# Altium schematic sheet 01-Power.SchDoc(01-Power)
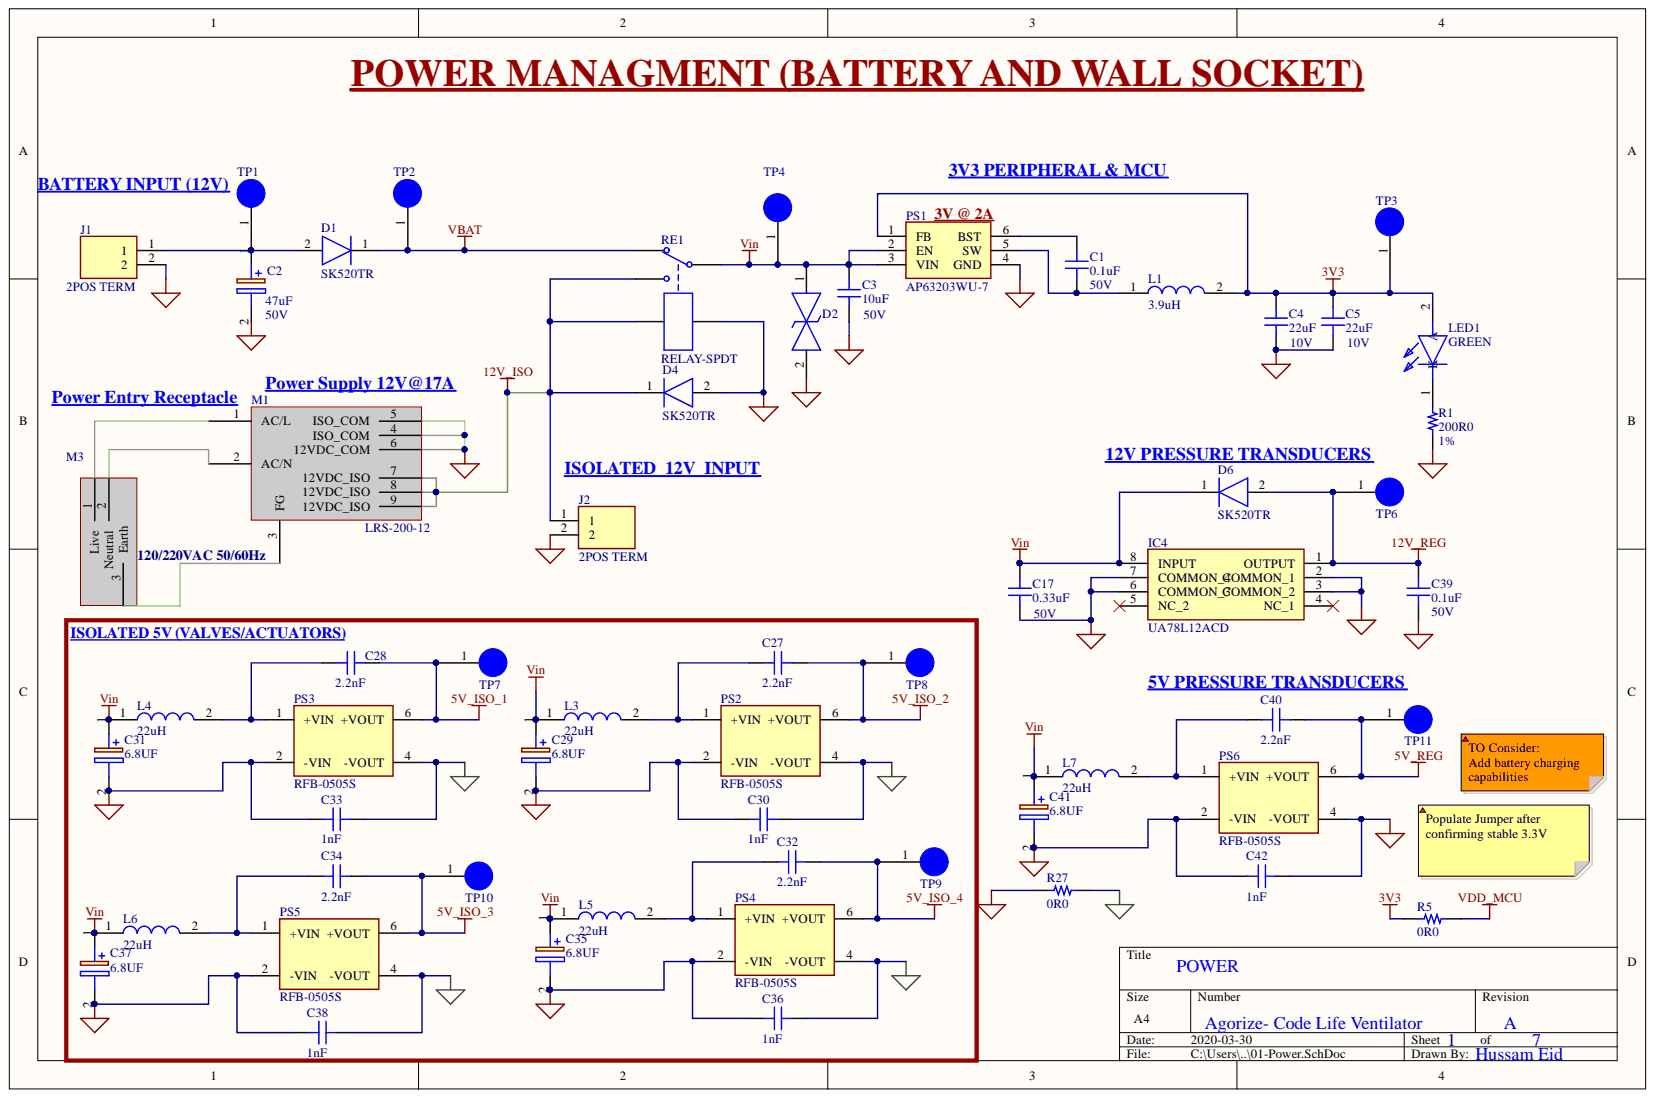
[diagram p0: 01-Power.SchDoc]

## Parts
C1  0.1uF  Voltage Rating (DC): 50V  [CAP 0603/1608]
C2  47uF  Voltage Rating (DC): 50V  [EEE1HA470XP]
C3  10uF  Voltage Rating (DC): 50V  [CAP 1206/3216]
C4  22uF  Voltage: 10V  [RES 0805/2012]
C5  22uF  Voltage: 10V  [RES 0805/2012]
C17  0.33uF  Voltage Rating (DC): 50V  [CAP 0603/1608]
C27  2.2nF  [CAP 0603/1608]
C28  2.2nF  [CAP 0603/1608]
C29  6.8UF  [CAPAE430X550N]
C30  1nF  [CAP 0603/1608]
C31  6.8UF  [CAPAE430X550N]
C32  2.2nF  [CAP 0603/1608]
C33  1nF  [CAP 0603/1608]
C34  2.2nF  [CAP 0603/1608]
C35  6.8UF  [CAPAE430X550N]
C36  1nF  [CAP 0603/1608]
C37  6.8UF  [CAPAE430X550N]
C38  1nF  [CAP 0603/1608]
C39  0.1uF  Voltage Rating (DC): 50V  [CAP 0603/1608]
C40  2.2nF  [CAP 0603/1608]
C41  6.8UF  [CAPAE430X550N]
C42  1nF  [CAP 0603/1608]
D1  SK520TR  [DIOM8060X295N]
D2  DF2B26M4SL,L3F  [TVS]  (value not drawn)
D4  SK520TR  [DIOM8060X295N]
D6  SK520TR  [DIOM8060X295N]
IC4  UA78L12ACD  [SOIC127P600X175-8N]
J1  2POS TERM  [SHDR2W110P0X500_1X2_1000X830X1140P]
J2  2POS TERM  [SHDR2W110P0X500_1X2_1000X830X1140P]
L1  3.9uH  [1255AY100MP3]
L3  22uH  [1255AY100MP3]
L4  22uH  [1255AY100MP3]
L5  22uH  [1255AY100MP3]
L6  22uH  [1255AY100MP3]
L7  22uH  [1255AY100MP3]
LED1  GREEN  [150060GS73220]
M1  Manufacturer Part Number 1: LRS-200-12  (hidden comment: 12V 204W PS)  (mechanical)
M3  Power Entry Receptacle  (mechanical)
PS1  AP63203WU-7  [SOT95P280X100-6N]
PS2  RFB-0505S  [RFB0505S]
PS3  RFB-0505S  [RFB0505S]
PS4  RFB-0505S  [RFB0505S]
PS5  RFB-0505S  [RFB0505S]
PS6  RFB-0505S  [RFB0505S]
R1  200R0  Tolerance: 1%  [RES 1206/3216]
R5  0R0  [RES 0603/1608]
R27  0R0  [RES 0603/1608]
RE1  RELAY-SPDT  [T9GV5L145]
TP1  TP  [TP]
TP2  TP  [TP]
TP3  TP  [TP]
TP4  TP  [TP]
TP6  TP  [TP]
TP7  TP  [TP]
TP8  TP  [TP]
TP9  TP  [TP]
TP10  TP  [TP]
TP11  TP  [TP]

## Nets
3V3: C4.2 C5.2 L1.2 LED1.2 PS1.1(FB) R5.1 TP3.1
5V_ISO_1: C28.2 PS3.6(+VOUT) TP7.1
5V_ISO_2: C27.2 PS2.6(+VOUT) TP8.1
5V_ISO_3: C34.2 PS5.6(+VOUT) TP10.1
5V_ISO_4: C32.2 PS4.6(+VOUT) TP9.1
5V_REG: C40.2 PS6.6(+VOUT) TP11.1
12V_ISO: D4.1 J2.1 M1.7(12VDC_ISO) M1.8(12VDC_ISO) M1.9(12VDC_ISO) RE1.3 RE1.4
12V_REG: C39.1 D6.2 IC4.1(OUTPUT) TP6.1
COM: C2.2 C3.1 C4.1 C5.1 C17.2 C29.2 C30.1 C31.2 C33.1 C35.2 C36.1 C37.2 C38.1 C39.2 C41.2 C42.1 C42.2 D2.2 D4.2 IC4.2(COMMON_1) IC4.3(COMMON_2) IC4.6(COMMON_3) IC4.7(COMMON_4) J1.2 J2.2 M1.4(ISO_COM) M1.5(ISO_COM) M1.6(12VDC_COM) PS1.4(GND) PS2.2(-VIN) PS3.2(-VIN) PS4.2(-VIN) PS5.2(-VIN) PS6.2(-VIN) PS6.4(-VOUT) R1.1 R27.1 RE1.2
COM_ISO: C30.2 C33.2 C36.2 C38.2 PS2.4(-VOUT) PS3.4(-VOUT) PS4.4(-VOUT) PS5.4(-VOUT) R27.2
VBAT: D1.1 RE1.5 TP2.1
VDD_MCU: R5.2
Vin: C3.2 C17.1 C29.1 C31.1 C35.1 C37.1 C41.1 D2.1 D6.1 IC4.8(INPUT) L3.1 L4.1 L5.1 L6.1 L7.1 PS1.2(EN) PS1.3(VIN) RE1.1 TP4.1
NetC1_1: C1.1 PS1.6(BST)
NetC1_2: C1.2 L1.1 PS1.5(SW)
NetC2_1: C2.1 D1.2 J1.1 TP1.1
NetC27_1: C27.1 L3.2 PS2.1(+VIN)
NetC28_1: C28.1 L4.2 PS3.1(+VIN)
NetC32_1: C32.1 L5.2 PS4.1(+VIN)
NetC34_1: C34.1 L6.2 PS5.1(+VIN)
NetC40_1: C40.1 L7.2 PS6.1(+VIN)
NetLED1_1: LED1.1 R1.2
NetM1_1: M1.1(AC/L) M3.1
NetM1_2: M1.2(AC/N) M3.2
NetM1_3: M1.3(FG) M3.3

Not connected: IC4 4(NC_1) 5(NC_2)

## Library data of the parts
C1 C39 = C1608X7R1E104K080AA: CAP CER 0.1UF 50V X7R 0603; Manufacturer Part Number 1: C0603C104M5RACTU; Manufacturer 1: KEMET; Tolerance: 20%; Dissipation Factor: 2.5%; Dielectric Material: Ceramic; Description 1: Cap Ceramic 0.1uF 50V X7R 20% SMD 0603 125C T/R; Database Table Name: Capacitor - Ceramic; Capacitance: 100nF; Width: 0.031inch; Voltage Rating: 50V; Thickness: 0.034inch; Termination: SMD/SMT; Supplier Part Number 1: 399-7845-1-ND; Supplier 1: Digi-Key; Stock 1: 3475152; RoHS 1: Yes; REACH SVHC: No SVHC; Radiation Hardening: No; Pricing 1: 1 = 0.10000, 10 = 0.03900, 100 = 0.01740, 500 = 0.01240, 1000 = 0.00974 (USD); Packaging: Tape and Reel; Package Quantity: 1; Mount: Surface Mount,PCB; Min Operating Temperature: -55°C; Max Operating Temperature: 125°C; Library Name: Celestial Library Database.DbLib; Length: 1.6mm; Lead Pitch: 0.7mm; Height: 0.8mm; Dielectric: X7R; Depth: 0.8mm; Contact Plating: Tin,Matte; ComponentLink16URL: http://www.farnell.com/datasheets/2746056.pdf?src-supplier=Element14; ComponentLink16Description: http://www.farnell.com/datasheets/2746056.pdf?src-supplier=Element14; ComponentLink15URL: http://www.farnell.com/datasheets/2315113.pdf?src-supplier=Newark; ComponentLink15Description: http://www.farnell.com/datasheets/2315113.pdf?src-supplier=Newark; ComponentLink14URL: http://capacitoredge.kemet.com/capedge2/DataSheet?pn=C0603C104M5RAC7867&src-supplier=Avnet; ComponentLink14Description: http://capacitoredge.kemet.com/capedge2/DataSheet?pn=C0603C104M5RAC7867&src-supplier=Avnet; ComponentLink13URL: https://www.verical.com/server-webapp/html/getDatasheet?partID=110138&src-supplier=Verical; ComponentLink13Description: https://www.verical.com/server-webapp/html/getDatasheet?partID=110138&src-supplier=Verical; ComponentLink12URL: https://api.kemet.com/component-edge/download/datasheet/C0603C104M5RACTU.pdf?src-supplier=Digi-Key; ComponentLink12Description: https://api.kemet.com/component-edge/download/datasheet/C0603C104M5RACTU.pdf?src-supplier=Digi-Key; ComponentLink11URL: http://capacitoredge.kemet.com/capedge2/DataSheet?pn=C0603C104M5RAC7867&src-supplier=Avnet+Asia; ComponentLink11Description: http://capacitoredge.kemet.com/capedge2/DataSheet?pn=C0603C104M5RAC7867&src-supplier=Avnet+Asia; ComponentLink10URL: http://www.farnell.com/datasheets/1828800.pdf?src-supplier=Newark; ComponentLink10Description: http://www.farnell.com/datasheets/1828800.pdf?src-supplier=Newark; ComponentLink9URL: http://www.farnell.com/datasheets/2746056.pdf?src-supplier=Newark; ComponentLink9Description: http://www.farnell.com/datasheets/2746056.pdf?src-supplier=Newark; ComponentLink8URL: http://components.arrow.com/part/dataview/40911037S8853915N7429?src-supplier=Arrow; ComponentLink8Description: http://components.arrow.com/part/dataview/40911037S8853915N7429?src-supplier=Arrow; ComponentLink7URL: http://www.alliedelec.com/m/d/6f3b5eb83d09db50ed28bdc730567b33.pdf?src-supplier=Allied+Electronics; ComponentLink7Description: http://www.alliedelec.com/m/d/6f3b5eb83d09db50ed28bdc730567b33.pdf?src-supplier=Allied+Electronics; ComponentLink6URL: http://www.mouser.com/ds/2/212/KEM_C1002_X7R_SMD-1102033.pdf?src-supplier=Mouser; ComponentLink6Description: http://www.mouser.com/ds/2/212/KEM_C1002_X7R_SMD-1102033.pdf?src-supplier=Mouser; ComponentLink5URL: http://components.arrow.com/part/dataview/0323_00313986?src-supplier=Arrow+Europe; ComponentLink5Description: http://components.arrow.com/part/dataview/0323_00313986?src-supplier=Arrow+Europe; ComponentLink4URL: http://www.farnell.com/datasheets/2746056.pdf?src-supplier=Farnell; ComponentLink4Description: http://www.farnell.com/datasheets/2746056.pdf?src-supplier=Farnell; ComponentLink3URL: https://www.verical.com/server-webapp/html/getDatasheet?partID=626892&src-supplier=Verical; ComponentLink3Description: https://www.verical.com/server-webapp/html/getDatasheet?partID=626892&src-supplier=Verical; ComponentLink2URL: http://digikey.com/product-detail/en/C1608X7R1E104K080AA/445-1316-1-ND/567697; ComponentLink2Description: Digi-Key listing; ComponentLink1URL: https://product.tdk.com/info/en/catalog/datasheets/mlcc_commercial_general_en.pdf; ComponentLink1Description: Datasheet; Component Kind: Standard; Case Code (Metric): 1608; Case Code (Imperial): 0603; Case/Package: 0603
C2 = EEE-1HA470XP: CAP ALUM 47UF 20% 50V SMD; Manufacturer Part Number 1: EEE-1HA470XAP; Manufacturer 1: Panasonic; Ripple Current: 105mA; Life (Hours): 2000Hour; Leakage Current: 3uA; Lead Diameter: 0.65mm; Dissipation Factor: 0.14%; Voltage Rating: 50V; Voltage: 50V; Tolerance: 20%; Termination: SMD/SMT; Supplier Part Number 1: PCE4622CT-ND; Supplier 1: Digi-Key; Stock 1: 1561; RoHS 1: Yes; Radiation Hardening: No; Pricing 1: 1 = 0.43000, 10 = 0.30600, 100 = 0.20180 (USD); Packaging: Tape and Reel; Package Quantity: 1; Number of Pins: 8; Mount: Surface Mount,PCB; Min Operating Temperature: -40°C; Max Operating Temperature: 85°C; Length: 8mm; Lead Pitch: 1.8mm; Lead Free: Lead Free; Height - Seated (Max): 0.303inch; Height: 7.8mm; Diameter: 6.3mm; Description 1: CAP ALUM 47UF 20% 50V SMD; ComponentLink5URL: https://datasheet.ciiva.com/2985/aba0000ce115-2985176.pdf?src-supplier=Avnet; ComponentLink5Description: https://datasheet.ciiva.com/2985/aba0000ce115-2985176.pdf?src-supplier=Avnet; ComponentLink4URL: https://datasheet.ciiva.com/30171/aba0000ce115-30171439.pdf?src-supplier=Onlinecomponents.com; ComponentLink4Description: https://datasheet.ciiva.com/30171/aba0000ce115-30171439.pdf?src-supplier=Onlinecomponents.com; ComponentLink3URL: https://datasheet.ciiva.com/14605/70255709-14605342.pdf?src-supplier=Allied+Electronics; ComponentLink3Description: https://datasheet.ciiva.com/14605/70255709-14605342.pdf?src-supplier=Allied+Electronics; ComponentLink2URL: https://datasheet.ciiva.com/17535/aba0000ce115-17535690.pdf?src-supplier=Avnet+Europe; ComponentLink2Description: https://datasheet.ciiva.com/17535/aba0000ce115-17535690.pdf?src-supplier=Avnet+Europe; ComponentLink1URL: https://datasheet.ciiva.com/2985/aba0000ce115-2985177.pdf?src-supplier=Avnet; ComponentLink1Description: https://datasheet.ciiva.com/2985/aba0000ce115-2985177.pdf?src-supplier=Avnet; Component Kind: Standard; Case/Package: Radial; Capacitance: 47uF
C3 = C1206C105M3RACTU: CAP CER 10UF 25V X7R 1206; Manufacturer Part Number 1: CL31A106MBHNNNE; Manufacturer 1: Samsung; Tolerance: 20%; Dielectric: X5R; Description 1: CAP CER 10UF 50V X5R 1206; Database Table Name: Capacitor - Ceramic; Width: 0.063inch; Voltage Rating: 50V; Thickness: 0.071inch; Supplier Part Number 1: 1276-6736-1-ND; Supplier 1: Digi-Key; Stock 1: 854671; RoHS 1: Yes; Pricing 1: 1 = 0.34000, 10 = 0.23000, 100 = 0.13040, 500 = 0.09206, 1000 = 0.08055 (USD); Packaging: Tape and Reel; Mount: Surface Mount; Min Operating Temperature: -55°C; Max Operating Temperature: 85°C; Library Name: Celestial Library Database.DbLib; Length: 0.126inch; ComponentLink3URL: http://www.samsungsem.com/kr/support/product-search/mlcc/CL31A106MBHNNNE.jsp?src-supplier=Digi-Key; ComponentLink3Description: http://www.samsungsem.com/kr/support/product-search/mlcc/CL31A106MBHNNNE.jsp?src-supplier=Digi-Key; ComponentLink2URL: http://digikey.com/product-detail/en/C1206C105M3RACTU/399-8148-2-ND/2215101; ComponentLink2Description: Digi-Key listing; ComponentLink1URL: http://www.kemet.com/docfinder?Partnumber=C1206C105M3RACTU; ComponentLink1Description: Datasheet; Component Kind: Standard; Case Code (Metric): 3216; Case Code (Imperial): 1206; Case/Package: 1206; Capacitance: 10uF
C4 C5 = C2012X7R1H104K085AA: CAP CER 22UF 10V X5R 0805; Manufacturer Part Number 1: LMK212BBJ226MG-T; Manufacturer 1: Taiyo Yuden; Tolerance: 20%; Description 1: CAP ,MLCC ,0805,10V,X5R,22 F 20% ,T&R; Database Table Name: Capacitor - Ceramic; Supplier Part Number 1: 587-5464-1-ND; Supplier 1: Digi-Key; Stock 1: 35; RoHS 1: Yes; Pricing 1: 1 = 0.30000, 10 = 0.20600, 100 = 0.11660, 500 = 0.08228, 1000 = 0.07200 (USD); Mount: Surface Mount; Min Operating Temperature: -55°C; Max Operating Temperature: 85°C; Library Name: Celestial Library Database.DbLib; Length: 2mm; Height: 1.25mm; Dielectric: X5R; Depth: 1.25mm; ComponentLink8URL: https://ds.yuden.co.jp/TYCOMPAS/ut/detail?pn=LMK212BBJ226MG-T &amp;u=M&src-supplier=Digi-Key; ComponentLink8Description: https://ds.yuden.co.jp/TYCOMPAS/ut/detail?pn=LMK212BBJ226MG-T &amp;u=M&src-supplier=Digi-Key; ComponentLink7URL: http://ds.yuden.co.jp/TYCOMPAS/ut/detail.do?productNo=LMK212BBJ226MG-T&fileName=LMK212BBJ226MG-T_SS&mode=specSheetDownload&src-supplier=Digi-Key; ComponentLink7Description: http://ds.yuden.co.jp/TYCOMPAS/ut/detail.do?productNo=LMK212BBJ226MG-T&fileName=LMK212BBJ226MG-T_SS&mode=specSheetDownload&src-supplier=Digi-Key; ComponentLink6URL: https://datasheet.ciiva.com/26787/cl21a226mqqnnne-26787006.pdf?src-supplier=IIIC; ComponentLink6Description: https://datasheet.ciiva.com/26787/cl21a226mqqnnne-26787006.pdf?src-supplier=IIIC; ComponentLink5URL: https://datasheet.ciiva.com/16361/cl21a226mqqnnne-16361189.pdf?src-supplier=Future+Electronics; ComponentLink5Description: https://datasheet.ciiva.com/16361/cl21a226mqqnnne-16361189.pdf?src-supplier=Future+Electronics; ComponentLink4URL: https://datasheet.ciiva.com/26838/getdatasheetpartid-357607-26838611.pdf?src-supplier=Verical; ComponentLink4Description: https://datasheet.ciiva.com/26838/getdatasheetpartid-357607-26838611.pdf?src-supplier=Verical; ComponentLink3URL: https://datasheet.ciiva.com/25796/cl21a226mqqnnne-25796195.pdf?src-supplier=Digi-Key; ComponentLink3Description: https://datasheet.ciiva.com/25796/cl21a226mqqnnne-25796195.pdf?src-supplier=Digi-Key; ComponentLink2URL: http://digikey.com/product-detail/en/C2012X7R1H104K085AA/445-7534-2-ND/2732969; ComponentLink2Description: Digi-Key listing; ComponentLink1URL: https://product.tdk.com/info/en/catalog/datasheets/mlcc_commercial_general_en.pdf; ComponentLink1Description: Datasheet; Component Kind: Standard; Case/Package: 0805; Capacitance: 22uF
C17 = C1608X7R1E104K080AA: CAP CER 0.33UF 50V X5R 0603; Manufacturer Part Number 1: C1608X5R1H334K080AB; Manufacturer 1: TDK; Tolerance: 10%; Dielectric Material: Ceramic; Description 1: TDK         C1608X5R1H334K080AB            SMD Multilayer Ceramic Capacitor, C Series, 0.33 F,  10%, X5R, 50 V, 0603 [1608 Metric]; Database Table Name: Capacitor - Ceramic; Capacitance: 330nF; Width: 0.031inch; Weight: 7.1E-05oz; Voltage Rating: 50V; Thickness: 0.035inch; Termination: SMD/SMT; Supplier Part Number 1: 445-7462-1-ND; Supplier 1: Digi-Key; Stock 1: 12717; RoHS 1: Yes; REACH SVHC: No SVHC; Radiation Hardening: No; Pricing 1: 1 = 0.23000, 10 = 0.15700, 100 = 0.07930, 500 = 0.05428, 1000 = 0.04802 (USD); Packaging: Tape and Reel; Package Quantity: 1; Number of Pins: 2; Mount: Surface Mount; Min Operating Temperature: -55°C; Max Operating Temperature: 85°C; Library Name: Celestial Library Database.DbLib; Length: 1.6mm; Lead Free: Lead Free; Height: 0.8mm; Dielectric: X5R; Depth: 0.8mm; Composition: Ceramic; ComponentLink20URL: https://datasheet.ciiva.com/7975/1796654-7975053.pdf?src-supplier=Element14; ComponentLink20Description: https://datasheet.ciiva.com/7975/1796654-7975053.pdf?src-supplier=Element14; ComponentLink19URL: https://datasheet.ciiva.com/22694/1796654-22694123.pdf?src-supplier=Element14; ComponentLink19Description: https://datasheet.ciiva.com/22694/1796654-22694123.pdf?src-supplier=Element14; ComponentLink18URL: https://datasheet.ciiva.com/6251/tdkmlcccaprange-6251126.pdf?src-supplier=Digi-Key; ComponentLink18Description: https://datasheet.ciiva.com/6251/tdkmlcccaprange-6251126.pdf?src-supplier=Digi-Key; ComponentLink17URL: https://datasheet.ciiva.com/26919/getdatasheetpartid-755505-26919191.pdf?src-supplier=Verical; ComponentLink17Description: https://datasheet.ciiva.com/26919/getdatasheetpartid-755505-26919191.pdf?src-supplier=Verical; ComponentLink16URL: http://www.farnell.com/datasheets/2746056.pdf?src-supplier=Element14; ComponentLink16Description: http://www.farnell.com/datasheets/2746056.pdf?src-supplier=Element14; ComponentLink15URL: http://www.farnell.com/datasheets/2315113.pdf?src-supplier=Newark; ComponentLink15Description: http://www.farnell.com/datasheets/2315113.pdf?src-supplier=Newark; ComponentLink14URL: http://capacitoredge.kemet.com/capedge2/DataSheet?pn=C0603C104M5RAC7867&src-supplier=Avnet; ComponentLink14Description: http://capacitoredge.kemet.com/capedge2/DataSheet?pn=C0603C104M5RAC7867&src-supplier=Avnet; ComponentLink13URL: https://www.verical.com/server-webapp/html/getDatasheet?partID=110138&src-supplier=Verical; ComponentLink13Description: https://www.verical.com/server-webapp/html/getDatasheet?partID=110138&src-supplier=Verical; ComponentLink12URL: https://api.kemet.com/component-edge/download/datasheet/C0603C104M5RACTU.pdf?src-supplier=Digi-Key; ComponentLink12Description: https://api.kemet.com/component-edge/download/datasheet/C0603C104M5RACTU.pdf?src-supplier=Digi-Key; ComponentLink11URL: http://capacitoredge.kemet.com/capedge2/DataSheet?pn=C0603C104M5RAC7867&src-supplier=Avnet+Asia; ComponentLink11Description: http://capacitoredge.kemet.com/capedge2/DataSheet?pn=C0603C104M5RAC7867&src-supplier=Avnet+Asia; ComponentLink10URL: http://www.farnell.com/datasheets/1828800.pdf?src-supplier=Newark; ComponentLink10Description: http://www.farnell.com/datasheets/1828800.pdf?src-supplier=Newark; ComponentLink9URL: http://www.farnell.com/datasheets/2746056.pdf?src-supplier=Newark; ComponentLink9Description: http://www.farnell.com/datasheets/2746056.pdf?src-supplier=Newark; ComponentLink8URL: http://components.arrow.com/part/dataview/40911037S8853915N7429?src-supplier=Arrow; ComponentLink8Description: http://components.arrow.com/part/dataview/40911037S8853915N7429?src-supplier=Arrow; ComponentLink7URL: http://www.alliedelec.com/m/d/6f3b5eb83d09db50ed28bdc730567b33.pdf?src-supplier=Allied+Electronics; ComponentLink7Description: http://www.alliedelec.com/m/d/6f3b5eb83d09db50ed28bdc730567b33.pdf?src-supplier=Allied+Electronics; ComponentLink6URL: http://www.mouser.com/ds/2/212/KEM_C1002_X7R_SMD-1102033.pdf?src-supplier=Mouser; ComponentLink6Description: http://www.mouser.com/ds/2/212/KEM_C1002_X7R_SMD-1102033.pdf?src-supplier=Mouser; ComponentLink5URL: http://components.arrow.com/part/dataview/0323_00313986?src-supplier=Arrow+Europe; ComponentLink5Description: http://components.arrow.com/part/dataview/0323_00313986?src-supplier=Arrow+Europe; ComponentLink4URL: http://www.farnell.com/datasheets/2746056.pdf?src-supplier=Farnell; ComponentLink4Description: http://www.farnell.com/datasheets/2746056.pdf?src-supplier=Farnell; ComponentLink3URL: https://www.verical.com/server-webapp/html/getDatasheet?partID=626892&src-supplier=Verical; ComponentLink3Description: https://www.verical.com/server-webapp/html/getDatasheet?partID=626892&src-supplier=Verical; ComponentLink2URL: http://digikey.com/product-detail/en/C1608X7R1E104K080AA/445-1316-1-ND/567697; ComponentLink2Description: Digi-Key listing; ComponentLink1URL: https://product.tdk.com/info/en/catalog/datasheets/mlcc_commercial_general_en.pdf; ComponentLink1Description: Datasheet; Component Kind: Standard; Case Code (Metric): 1608; Case Code (Imperial): 0603; Case/Package: 0603
C27 C28 C32 C34 C40 = C1608X7R1E104K080AA: CAP CER 2.2nF 50V X7R 0603; Manufacturer Part Number 1: C0603C104M5RACTU; Manufacturer 1: KEMET; Tolerance: 20%; Dissipation Factor: 2.5%; Dielectric Material: Ceramic; Description 1: Cap Ceramic 0.1uF 50V X7R 20% SMD 0603 125C T/R; Database Table Name: Capacitor - Ceramic; Capacitance: 100nF; Width: 0.031inch; Voltage Rating (DC): 50V; Voltage Rating: 50V; Thickness: 0.034inch; Termination: SMD/SMT; Supplier Part Number 1: 399-7845-1-ND; Supplier 1: Digi-Key; Stock 1: 3158249; RoHS 1: Yes; REACH SVHC: No SVHC; Radiation Hardening: No; Pricing 1: 1 = 0.10000, 10 = 0.03900, 100 = 0.01740, 500 = 0.01240, 1000 = 0.00974 (USD); Packaging: Tape and Reel; Package Quantity: 1; Mount: Surface Mount,PCB; Min Operating Temperature: -55°C; Max Operating Temperature: 125°C; Library Name: Celestial Library Database.DbLib; Length: 1.6mm; Lead Pitch: 0.7mm; Height: 0.8mm; Dielectric: X7R; Depth: 0.8mm; Contact Plating: Tin,Matte; ComponentLink16URL: http://www.farnell.com/datasheets/2746056.pdf?src-supplier=Element14; ComponentLink16Description: http://www.farnell.com/datasheets/2746056.pdf?src-supplier=Element14; ComponentLink15URL: http://www.farnell.com/datasheets/2315113.pdf?src-supplier=Newark; ComponentLink15Description: http://www.farnell.com/datasheets/2315113.pdf?src-supplier=Newark; ComponentLink14URL: http://capacitoredge.kemet.com/capedge2/DataSheet?pn=C0603C104M5RAC7867&src-supplier=Avnet; ComponentLink14Description: http://capacitoredge.kemet.com/capedge2/DataSheet?pn=C0603C104M5RAC7867&src-supplier=Avnet; ComponentLink13URL: https://www.verical.com/server-webapp/html/getDatasheet?partID=110138&src-supplier=Verical; ComponentLink13Description: https://www.verical.com/server-webapp/html/getDatasheet?partID=110138&src-supplier=Verical; ComponentLink12URL: https://api.kemet.com/component-edge/download/datasheet/C0603C104M5RACTU.pdf?src-supplier=Digi-Key; ComponentLink12Description: https://api.kemet.com/component-edge/download/datasheet/C0603C104M5RACTU.pdf?src-supplier=Digi-Key; ComponentLink11URL: http://capacitoredge.kemet.com/capedge2/DataSheet?pn=C0603C104M5RAC7867&src-supplier=Avnet+Asia; ComponentLink11Description: http://capacitoredge.kemet.com/capedge2/DataSheet?pn=C0603C104M5RAC7867&src-supplier=Avnet+Asia; ComponentLink10URL: http://www.farnell.com/datasheets/1828800.pdf?src-supplier=Newark; ComponentLink10Description: http://www.farnell.com/datasheets/1828800.pdf?src-supplier=Newark; ComponentLink9URL: http://www.farnell.com/datasheets/2746056.pdf?src-supplier=Newark; ComponentLink9Description: http://www.farnell.com/datasheets/2746056.pdf?src-supplier=Newark; ComponentLink8URL: http://components.arrow.com/part/dataview/40911037S8853915N7429?src-supplier=Arrow; ComponentLink8Description: http://components.arrow.com/part/dataview/40911037S8853915N7429?src-supplier=Arrow; ComponentLink7URL: http://www.alliedelec.com/m/d/6f3b5eb83d09db50ed28bdc730567b33.pdf?src-supplier=Allied+Electronics; ComponentLink7Description: http://www.alliedelec.com/m/d/6f3b5eb83d09db50ed28bdc730567b33.pdf?src-supplier=Allied+Electronics; ComponentLink6URL: http://www.mouser.com/ds/2/212/KEM_C1002_X7R_SMD-1102033.pdf?src-supplier=Mouser; ComponentLink6Description: http://www.mouser.com/ds/2/212/KEM_C1002_X7R_SMD-1102033.pdf?src-supplier=Mouser; ComponentLink5URL: http://components.arrow.com/part/dataview/0323_00313986?src-supplier=Arrow+Europe; ComponentLink5Description: http://components.arrow.com/part/dataview/0323_00313986?src-supplier=Arrow+Europe; ComponentLink4URL: http://www.farnell.com/datasheets/2746056.pdf?src-supplier=Farnell; ComponentLink4Description: http://www.farnell.com/datasheets/2746056.pdf?src-supplier=Farnell; ComponentLink3URL: https://www.verical.com/server-webapp/html/getDatasheet?partID=626892&src-supplier=Verical; ComponentLink3Description: https://www.verical.com/server-webapp/html/getDatasheet?partID=626892&src-supplier=Verical; ComponentLink2URL: http://digikey.com/product-detail/en/C1608X7R1E104K080AA/445-1316-1-ND/567697; ComponentLink2Description: Digi-Key listing; ComponentLink1URL: https://product.tdk.com/info/en/catalog/datasheets/mlcc_commercial_general_en.pdf; ComponentLink1Description: Datasheet; Component Kind: Standard; Case Code (Metric): 1608; Case Code (Imperial): 0603; Case/Package: 0603
C29 C31 C35 C37 C41 = CAP_ELECTROLYTIC: CAP 6.8 UF 20% 50 V; Manufacturer Part Number 1: 865080642005; Manufacturer 1: Wurth Electronics; Voltage Rating (DC): 50V; Ripple Current (AC): 75mA; Polarity: Polar; Material: Aluminium; Life (Hours): 2000Hour; Impedance: 3.8R; Voltage Rating: 50V; Tolerance: 20%; Supplier Part Number 1: 732-8450-1-ND; Supplier 1: Digi-Key; Stock 1: 76; RoHS 1: Yes; Ripple Current: 75mA; REACH SVHC: Unknown; Pricing 1: 1 = 0.20000, 50 = 0.17000, 100 = 0.14800, 250 = 0.13200, 500 = 0.11500 (USD); Packaging: Cut Tape; Package Quantity: 1000; Mount: Surface Mount; Min Operating Temperature: -55°C; Max Operating Temperature: 105°C; Library Name: Schlib1.SchLib; Height - Seated (Max): 0.217inch; Height: 5.5mm; Diameter: 5mm; Description 1: CAP 6.8 UF 20% 50 V; ComponentLink1URL: https://datasheet.ciiva.com/48693/001280473-da-01-en-wcap-asli-alu-elec-caps-865080642005-48693548.pdf?src-supplier=Conrad; ComponentLink1Description: https://datasheet.ciiva.com/48693/001280473-da-01-en-wcap-asli-alu-elec-caps-865080642005-48693548.pdf?src-supplier=Conrad; Component Kind: Standard; Case/Package: Radial; Capacitance: 6.8uF
C30 C33 C36 C38 C42 = C1608X7R1E104K080AA: CAP CER 1nF 50V X7R 0603; Manufacturer Part Number 1: C0603C104M5RACTU; Manufacturer 1: KEMET; Tolerance: 20%; Dissipation Factor: 2.5%; Dielectric Material: Ceramic; Description 1: Cap Ceramic 0.1uF 50V X7R 20% SMD 0603 125C T/R; Database Table Name: Capacitor - Ceramic; Capacitance: 100nF; Width: 0.031inch; Voltage Rating (DC): 50V; Voltage Rating: 50V; Thickness: 0.034inch; Termination: SMD/SMT; Supplier Part Number 1: 399-7845-1-ND; Supplier 1: Digi-Key; Stock 1: 3158249; RoHS 1: Yes; REACH SVHC: No SVHC; Radiation Hardening: No; Pricing 1: 1 = 0.10000, 10 = 0.03900, 100 = 0.01740, 500 = 0.01240, 1000 = 0.00974 (USD); Packaging: Tape and Reel; Package Quantity: 1; Mount: Surface Mount,PCB; Min Operating Temperature: -55°C; Max Operating Temperature: 125°C; Library Name: Celestial Library Database.DbLib; Length: 1.6mm; Lead Pitch: 0.7mm; Height: 0.8mm; Dielectric: X7R; Depth: 0.8mm; Contact Plating: Tin,Matte; ComponentLink16URL: http://www.farnell.com/datasheets/2746056.pdf?src-supplier=Element14; ComponentLink16Description: http://www.farnell.com/datasheets/2746056.pdf?src-supplier=Element14; ComponentLink15URL: http://www.farnell.com/datasheets/2315113.pdf?src-supplier=Newark; ComponentLink15Description: http://www.farnell.com/datasheets/2315113.pdf?src-supplier=Newark; ComponentLink14URL: http://capacitoredge.kemet.com/capedge2/DataSheet?pn=C0603C104M5RAC7867&src-supplier=Avnet; ComponentLink14Description: http://capacitoredge.kemet.com/capedge2/DataSheet?pn=C0603C104M5RAC7867&src-supplier=Avnet; ComponentLink13URL: https://www.verical.com/server-webapp/html/getDatasheet?partID=110138&src-supplier=Verical; ComponentLink13Description: https://www.verical.com/server-webapp/html/getDatasheet?partID=110138&src-supplier=Verical; ComponentLink12URL: https://api.kemet.com/component-edge/download/datasheet/C0603C104M5RACTU.pdf?src-supplier=Digi-Key; ComponentLink12Description: https://api.kemet.com/component-edge/download/datasheet/C0603C104M5RACTU.pdf?src-supplier=Digi-Key; ComponentLink11URL: http://capacitoredge.kemet.com/capedge2/DataSheet?pn=C0603C104M5RAC7867&src-supplier=Avnet+Asia; ComponentLink11Description: http://capacitoredge.kemet.com/capedge2/DataSheet?pn=C0603C104M5RAC7867&src-supplier=Avnet+Asia; ComponentLink10URL: http://www.farnell.com/datasheets/1828800.pdf?src-supplier=Newark; ComponentLink10Description: http://www.farnell.com/datasheets/1828800.pdf?src-supplier=Newark; ComponentLink9URL: http://www.farnell.com/datasheets/2746056.pdf?src-supplier=Newark; ComponentLink9Description: http://www.farnell.com/datasheets/2746056.pdf?src-supplier=Newark; ComponentLink8URL: http://components.arrow.com/part/dataview/40911037S8853915N7429?src-supplier=Arrow; ComponentLink8Description: http://components.arrow.com/part/dataview/40911037S8853915N7429?src-supplier=Arrow; ComponentLink7URL: http://www.alliedelec.com/m/d/6f3b5eb83d09db50ed28bdc730567b33.pdf?src-supplier=Allied+Electronics; ComponentLink7Description: http://www.alliedelec.com/m/d/6f3b5eb83d09db50ed28bdc730567b33.pdf?src-supplier=Allied+Electronics; ComponentLink6URL: http://www.mouser.com/ds/2/212/KEM_C1002_X7R_SMD-1102033.pdf?src-supplier=Mouser; ComponentLink6Description: http://www.mouser.com/ds/2/212/KEM_C1002_X7R_SMD-1102033.pdf?src-supplier=Mouser; ComponentLink5URL: http://components.arrow.com/part/dataview/0323_00313986?src-supplier=Arrow+Europe; ComponentLink5Description: http://components.arrow.com/part/dataview/0323_00313986?src-supplier=Arrow+Europe; ComponentLink4URL: http://www.farnell.com/datasheets/2746056.pdf?src-supplier=Farnell; ComponentLink4Description: http://www.farnell.com/datasheets/2746056.pdf?src-supplier=Farnell; ComponentLink3URL: https://www.verical.com/server-webapp/html/getDatasheet?partID=626892&src-supplier=Verical; ComponentLink3Description: https://www.verical.com/server-webapp/html/getDatasheet?partID=626892&src-supplier=Verical; ComponentLink2URL: http://digikey.com/product-detail/en/C1608X7R1E104K080AA/445-1316-1-ND/567697; ComponentLink2Description: Digi-Key listing; ComponentLink1URL: https://product.tdk.com/info/en/catalog/datasheets/mlcc_commercial_general_en.pdf; ComponentLink1Description: Datasheet; Component Kind: Standard; Case Code (Metric): 1608; Case Code (Imperial): 0603; Case/Package: 0603
D1 D4 D6 = SK520TR: DIODE SCHOTTKY 200V 5A SMC; Supplier Part Number 1: 1655-1603-1-ND; Supplier 1: Digi-Key; Stock 1: 19874; RoHS 1: No; Pricing 1: 1 = 0.35000, 10 = 0.28500, 25 = 0.26040, 100 = 0.16360, 250 = 0.16176, 500 = 0.15148, 1000 = 0.10300 (USD); Packaging: Tape and Reel; Mount: Surface Mount; Max Reverse Voltage (DC): 200V; Manufacturer Part Number 1: SK520TR; Manufacturer 1: SMC; Description 1: DIODE SCHOTTKY 200V 5A SMC; ComponentLink1URL: http://www.smc-diodes.com/propdf/SK520%20N0107%20REV.A.pdf?src-supplier=Digi-Key; ComponentLink1Description: http://www.smc-diodes.com/propdf/SK520%20N0107%20REV.A.pdf?src-supplier=Digi-Key; Component Kind: Standard; Case/Package: SMC; Average Rectified Current: 5A
D2 = 1KSMB12CA: BI-DIRECTIONAL ESD PROTECTION DI; Manufacturer 1: Toshiba Semiconductor and Storage; Manufacturer Part Number 1: DF2B26M4SL,L3F; Component Kind: Standard
IC4 = UA78L12ACD: Linear Voltage Regulator IC  1 Output  100mA 8-SOIC; Manufacturer 1: Texas Instruments; RS Price/Stock: http://uk.rs-online.com/web/p/products/6616727P; RS Part Number: 6616727P; Mouser Price/Stock: https://www.mouser.com/Search/Refine.aspx?Keyword=595-UA78L12ACD; Mouser Part Number: 595-UA78L12ACD; Manufacturer Part Number 1: UA78L12ACD; Manufacturer_Part_Number: UA78L12ACD; Height: 1.75mm; Datasheet Link: http://www.ti.com/lit/ds/symlink/ua78l12a.pdf; Component Kind: Standard
J1 J2 = 1935161: TERM BLK 2POS SIDE ENTRY 5MM PCB; Manufacturer 1: Phoenix Contact; Wire Gauge (Min): 12AWG; Wire Gauge (Max): 26AWG; Voltage Rating (DC): 320V; Voltage Rating: 250V; Voltage: 300V; Number of Positions Per Level: 2; Number of Levels: 1; Max Current Rating: 16A; Description 1: Conn Terminal Blocks 2 POS 5mm Solder ST Thru-Hole 16A; Current Rating: 17.5A; Current: 18A; Connector Type: Screw; Approvals: UL; Wire/Cable Gauge: 26AWG; Width: 14.9mm; Weight: 0.067021oz; Termination: Solder; Supplier Part Number 1: 277-1667-ND; Supplier 1: Digi-Key; Stock 1: 32563; RoHS 1: Yes; REACH SVHC: Unknown; Radiation Hardening: No; Pricing 1: 1 = 0.43000, 10 = 0.41100, 50 = 0.39280, 100 = 0.38200, 250 = 0.35700, 500 = 0.32844, 1000 = 0.30350 (USD); Pitch: 5mm; Packaging: Bulk; Package Quantity: 250; Orientation: Straight; Number of Rows: 1; Number of Positions: 2; Number of Poles: 2; Number of Pins: 2; Number of Contacts: 2; Number of Circuits: 2; Mount: Through Hole; Max Supply Voltage: 250V; Material: Nylon; Manufacturer Part Number 1: 1935161; Length: 9mm; Lead Free: Lead Free; Insulation Material: Nylon; Housing Material: Polyamide; Housing Color: Green; Height: 11.3mm; Flammability Rating: UL94 V-0; Depth: 9mm; Contact Material: Copper,Tin; ComponentLink3URL: https://datasheet.ciiva.com/824/combiconcompact5-0mmseries-824222.pdf?src-supplier=Digi-Key; ComponentLink3Description: https://datasheet.ciiva.com/824/combiconcompact5-0mmseries-824222.pdf?src-supplier=Digi-Key; ComponentLink2URL: https://datasheet.ciiva.com/16047/1935161-16047247.pdf?src-supplier=Onlinecomponents.com; ComponentLink2Description: https://datasheet.ciiva.com/16047/1935161-16047247.pdf?src-supplier=Onlinecomponents.com; ComponentLink1URL: https://datasheet.ciiva.com/15169/getdatasheetpartid-177903-15169663.pdf?src-supplier=Verical; ComponentLink1Description: https://datasheet.ciiva.com/15169/getdatasheetpartid-177903-15169663.pdf?src-supplier=Verical; Component Kind: Standard; Color: Green
L1 = 1255AY-3R9N=P3: 3.9µH Shielded Wirewound Inductor 4.2A 26.4mOhm Max Nonstandard; Manufacturer 1: Murata; Tolerance: 30%; Test Frequency: 100kHz; Saturation Current: 4.7A; Description 1: FIXED IND; DC Resistance (DCR): 26.4mR; Core Material: Ferrite; Width: 0.248inch; Supplier Part Number 1: 490-14062-1-ND; Supplier 1: Digi-Key; Stock 1: 385; Shielding: Shielded; RoHS 1: Yes; Pricing 1: 1 = 0.48000, 10 = 0.42300, 100 = 0.30190, 500 = 0.25358 (USD); Packaging: Tape and Reel; Mount: Surface Mount; Min Operating Temperature: -40°C; Max Operating Temperature: 85°C; Manufacturer Part Number 1: 1255AY-3R9N=P3; Length: 0.248inch; Inductance: 3.9uH; Height - Seated (Max): 0.177inch; Current Rating: 4.2A; Composition: Wirewound; ComponentLink1URL: http://www.toko.co.jp/products/pdf/inductors/dg6045c.pdf?src-supplier=Digi-Key; ComponentLink1Description: http://www.toko.co.jp/products/pdf/inductors/dg6045c.pdf?src-supplier=Digi-Key; Component Kind: Standard; Case/Package: Nonstandard
L3-L7 = 1255AY-3R9N=P3: Inductor Unshielded Wirewound  190mA 4.81Ohm Max 0805 (2012 Metric); Manufacturer Part Number 1: CBC2012T220M; Manufacturer 1: Taiyo Yuden; Tolerance: 20%; Test Frequency: 2.52MHz; Self Resonant Frequency: 16MHz; Saturation Current: 170mA; Description 1: Inductor Power Chip Wirewound 22uH 20% 2.52MHz Ferrite 190mA 4.81Ohm DCR 0805 T/R; DC Resistance (DCR): 4.81R; Core Material: Ferrite; Width: 0.049inch; Termination: SMD/SMT; Supplier Part Number 1: 587-1604-1-ND; Supplier 1: Digi-Key; Stock 1: 8981; Shielding: Unshielded; RoHS 1: Yes; Pricing 1: 1 = 0.24000, 10 = 0.21600, 100 = 0.14860, 500 = 0.12702, 1000 = 0.09729 (USD); Packaging: Tape and Reel; Package Quantity: 3000; Number of Pins: 2; Mount: Surface Mount; Min Operating Temperature: -40°C; Max Operating Temperature: 105°C; Max DC Current: 170mA; Length: 0.079inch; Lead Free: Exempt; Inductance: 22uH; Height - Seated (Max): 0.057inch; Height: 1.25mm; Halogen Free: Halogen Free; Current Rating: 190mA; Composition: Wirewound; ComponentLink3URL: https://datasheet.ciiva.com/1040/cbc2012t220m-ss-1040471.pdf?src-supplier=Digi-Key; ComponentLink3Description: https://datasheet.ciiva.com/1040/cbc2012t220m-ss-1040471.pdf?src-supplier=Digi-Key; ComponentLink2URL: https://datasheet.ciiva.com/8505/cbc2012t220m-ss-8505651.pdf?src-supplier=Avnet; ComponentLink2Description: https://datasheet.ciiva.com/8505/cbc2012t220m-ss-8505651.pdf?src-supplier=Avnet; ComponentLink1URL: http://www.toko.co.jp/products/pdf/inductors/dg6045c.pdf?src-supplier=Digi-Key; ComponentLink1Description: http://www.toko.co.jp/products/pdf/inductors/dg6045c.pdf?src-supplier=Digi-Key; Component Kind: Standard; Case Code (Metric): 2012; Case Code (Imperial): 0805; Case/Package: 0805
LED1 = 150060GS73220: LED GREEN CLEAR 0603 SMD; Manufacturer Part Number 1: 150060VS75000; Manufacturer 1: Wurth Electronics; Wavelength: 570nm; Viewing Angle: 140°; Test Current: 20mA; Luminous Intensity: 40mcd; Lens Style: Clear,Rectangular; Forward Voltage: 2V; Forward Current: 30mA; Description 1: WURTH ELEKTRONIK - 150060VS75000 - LED, Grün, Oberflächenmontage, 603, 30 mA, 2 V, 570 nm; Width: 0.8mm; Supplier Part Number 1: 732-4980-1-ND; Supplier 1: Digi-Key; Stock 1: 29468; RoHS 1: Yes; REACH SVHC: Unknown; Pricing 1: 1 = 0.14000, 50 = 0.13300, 100 = 0.11400, 500 = 0.09900 (USD); Packaging: Tape and Reel; Package Quantity: 1; Number of Pins: 2; Mount: Surface Mount; Min Operating Temperature: -40°C; Max Operating Temperature: 85°C; Length: 1.6mm; Height: 0.7mm; Dominant Wavelength: 570nm; ComponentLink1URL: https://datasheet.ciiva.com/29562/150060vs75000-29562919.pdf?src-supplier=Digi-Key; ComponentLink1Description: https://datasheet.ciiva.com/29562/150060vs75000-29562919.pdf?src-supplier=Digi-Key; Component Kind: Standard; Color: Green; Case Code (Metric): 1608; Case Code (Imperial): 0603; Case/Package: 0603
M1 = 12V 204W PS: Enclosed AC DC Converters 1 Output 12V    17A 90 ~ 132 VAC, 180 ~ 264 VAC, 240 ~ 370 VDC Input; Manufacturer 1: Mean Well; Number of Outputs: 1; Max Output Power: 200W; Max Input Current: 4A; Isolation Voltage: 3kV; Efficiency: 87.5%; Description 1: 204W 12V 17A Single Output Switchable Power Supply; Approvals: UL; Width: 115mm; Weight: 1.455lb; Voltage - Output 1: 12V; Supplier Part Number 1: 1866-3330-ND; Supplier 1: Digi-Key; Stock 1: 186; RoHS 1: Yes; Pricing 1: 1 = 30.51000, 10 = 28.71700, 25 = 27.81920, 50 = 26.92180 (USD); Packaging: Bulk; Package Quantity: 15; Output Power: 204W; Output Current: 17A; Mount: Panel; Min Operating Temperature: -25°C; Max Operating Temperature: 70°C; Library Name: Schlib1.SchLib; Length: 215mm; Lead Free: Lead Free; Height: 30mm; Current - Output 1: 17A; ComponentLink2URL: https://datasheet.ciiva.com/43506/2219727-43506012.pdf?src-supplier=Jameco; ComponentLink2Description: https://datasheet.ciiva.com/43506/2219727-43506012.pdf?src-supplier=Jameco; ComponentLink1URL: https://datasheet.ciiva.com/43509/lrs-200-spec-43509573.pdf?src-supplier=Onlinecomponents.com; ComponentLink1Description: https://datasheet.ciiva.com/43509/lrs-200-spec-43509573.pdf?src-supplier=Onlinecomponents.com; Color: Silver
M3 = Power Entry Receptacle: Power Entry Connector Receptacle, Male Blades IEC 320-C14 Panel Mount, Flange; Manufacturer Part Number 1: FN9222B-1-06; Manufacturer 1: Schaffner; Voltage Rating (AC): 250V; Resistance: 1MR; Ratings: IP40; Number of Positions: 3; Number of Ports: 1; Max Current Rating: 1A; Inductance: 12mH; Fuse: No; Frequency: 400Hz; Description 1: PWR ENT RCPT IEC320-C14 PANEL QC; Capacitance: 100nF; Approvals: UL,CSA,ENEC,CQC,VDE; Width: 22.5mm; Voltage Rating: 250V; Termination: Solder; Supplier Part Number 1: 817-1428-ND; Supplier 1: Digi-Key; Stock 1: 242; RoHS 1: Yes; REACH SVHC: No SVHC; Radiation Hardening: No; Pricing 1: 1 = 5.75000, 10 = 5.52000, 25 = 5.06000, 50 = 4.83000, 100 = 4.60000, 250 = 4.02500, 500 = 3.91000, 1000 = 3.33500, 2500 = 3.10500 (USD); Packaging: Bulk; Package Quantity: 60; Orientation: Straight; Number of Poles: 3; Number of Contacts: 3; Mount: Panel,Flanges,Screw; Min Operating Temperature: -25°C; Max Voltage Rating (AC): 250V; Max Operating Temperature: 85°C; Library Name: Schlib1.SchLib; Height: 48mm; Gender: Male; Flammability Rating: UL94 V-2; Depth: 43.9mm; Current Rating: 1A; Current: 1A; Connector Type: Receptacle; ComponentLink5URL: https://datasheet.ciiva.com/1019/fn+9222-1019406.pdf?src-supplier=Digi-Key; ComponentLink5Description: https://datasheet.ciiva.com/1019/fn+9222-1019406.pdf?src-supplier=Digi-Key; ComponentLink4URL: https://datasheet.ciiva.com/46431/1851815-46431020.pdf?src-supplier=Element14; ComponentLink4Description: https://datasheet.ciiva.com/46431/1851815-46431020.pdf?src-supplier=Element14; ComponentLink3URL: https://datasheet.ciiva.com/30243/138index-phpid329l0w4-productsproduct70chash7322c530e83bcf7af0b2e347-30243243.pdf?src-supplier=Onlinecomponents.com; ComponentLink3Description: https://datasheet.ciiva.com/30243/138index-phpid329l0w4-productsproduct70chash7322c530e83bcf7af0b2e347-30243243.pdf?src-supplier=Onlinecomponents.com; ComponentLink2URL: https://datasheet.ciiva.com/4582/-4582696.pdf?src-supplier=Mouser; ComponentLink2Description: https://datasheet.ciiva.com/4582/-4582696.pdf?src-supplier=Mouser; ComponentLink1URL: https://datasheet.ciiva.com/14563/70027175-14563477.pdf?src-supplier=Allied+Electronics; ComponentLink1Description: https://datasheet.ciiva.com/14563/70027175-14563477.pdf?src-supplier=Allied+Electronics; Case/Package: Module
PS1 = AP63203WU-7: IC REG BUCK 3.3V 2A TSOT26; Manufacturer 1: Diodes; Description 1: AP63203 Series 3.3 V 2 A SMT Fixed Low IQ Synchronous Buck - TSOT-26; Supplier Part Number 1: AP63203WU-7DICT-ND; Supplier 1: Digi-Key; Stock 1: 8615; RoHS 1: No; Pricing 1: 1 = 0.83000, 10 = 0.74500, 25 = 0.70720, 100 = 0.54290, 250 = 0.47976, 500 = 0.45450, 1000 = 0.35350 (USD); Manufacturer Part Number 1: AP63203WU-7; ComponentLink4URL: http://www.samsungsem.com/kr/support/product-search/mlcc/CL31A106MBHNNNE.jsp?src-supplier=Digi-Key; ComponentLink4Description: http://www.samsungsem.com/kr/support/product-search/mlcc/CL31A106MBHNNNE.jsp?src-supplier=Digi-Key; ComponentLink3URL: http://www.farnell.com/datasheets/2741240.pdf?src-supplier=Newark; ComponentLink3Description: http://www.farnell.com/datasheets/2741240.pdf?src-supplier=Newark; ComponentLink2URL: https://api.kemet.com/component-edge/download/datasheet/C0603C104K5RECAUTO.pdf?src-supplier=Digi-Key; ComponentLink2Description: https://api.kemet.com/component-edge/download/datasheet/C0603C104K5RECAUTO.pdf?src-supplier=Digi-Key; ComponentLink1URL: http://www.farnell.com/datasheets/2741240.pdf?src-supplier=Farnell; ComponentLink1Description: http://www.farnell.com/datasheets/2741240.pdf?src-supplier=Farnell; Component Kind: Standard
PS2-PS6 = RFB-0505S: DC DC CONVERTER 5V 1W; Manufacturer_Name: RECOM Power; Manufacturer 1: Recom; Number of Outputs: 1; Max Output Current: 200mA; Isolation Voltage: 1kV; Efficiency: 80%; Description 1: Recom RFB 1W Isolated DC-DC Converter Through Hole, Voltage out 5V dc; Width: 6mm; Weight: 2.2g; Voltage - Output 1: 5V; Supplier Part Number 1: 945-3158-ND; Supplier 1: Digi-Key; Stock 1: 1808; RS Price/Stock: http://uk.rs-online.com/web/p/products/1855539P; RS Part Number: 1855539P; RoHS 1: No; Pricing 1: 1 = 1.54000, 5 = 1.51800, 10 = 1.49600, 25 = 1.45200, 50 = 1.40800, 100 = 1.36400, 250 = 1.32000, 500 = 1.23200 (USD); Packaging: Rail/Tube; Mouser Price/Stock: https://www.mouser.com/Search/Refine.aspx?Keyword=919-RFB-0505S; Mouser Part Number: 919-RFB-0505S; Mount: Through Hole; Min Operating Temperature: -40°C; Max Power Dissipation: 1W; Max Output Voltage: 5V; Max Operating Temperature: 85°C; Max Input Voltage: 5V; Manufacturer Part Number 1: RFB-0505S; Manufacturer_Part_Number: RFB-0505S; Library Name: Schlib1.SchLib; Length: 19.6mm; Height: 10.7mm; Features: SCP; Datasheet Link: https://componentsearchengine.com/Datasheets/1/RFB-0505S.pdf; ComponentLink1URL: https://www.recom-power.com/pdf/Econoline/RFB.pdf?src-supplier=Digi-Key; ComponentLink1Description: https://www.recom-power.com/pdf/Econoline/RFB.pdf?src-supplier=Digi-Key; Component Kind: Standard
R1 = ERJ-8ENF1002V: RES SMD 10K OHM 1% 1/4W 1206; Manufacturer Part Number 1: RC1206FR-07200RL; Manufacturer 1: Yageo; Voltage Rating (DC): 200V; Temperature Coefficient: 100ppm/°C; Resistance: 200R; Power Rating: 250mW; Description 1: Res Thick Film 1206 200 Ohm 1% 0.25W(1/4W) ±100ppm/C Molded SMD Paper T/R; Database Table Name: Resistor - Chip; Width: 1.6mm; Weight: 0.000571oz; Voltage Rating: 200V; Termination: SMD/SMT; Supplier Part Number 1: 311-200FRCT-ND; Supplier 1: Digi-Key; Stock 1: 2870115; RoHS 1: Yes; Pricing 1: 1 = 0.10000, 10 = 0.07100, 100 = 0.02910, 1000 = 0.01306, 2500 = 0.01134 (USD); Packaging: Tape and Reel; Mount: Surface Mount; Min Operating Temperature: -55°C; Military Standard: Not; Max Operating Temperature: 155°C; Library Name: Celestial Library Database.DbLib; Length: 3.1mm; Lead Free: Lead Free; Height: 0.55mm; Depth: 1.6mm; Composition: Thick Film; ComponentLink3URL: https://datasheet.ciiva.com/11808/0900766b80c6630a-11808603.pdf?src-supplier=RSComponents; ComponentLink3Description: https://datasheet.ciiva.com/11808/0900766b80c6630a-11808603.pdf?src-supplier=RSComponents; ComponentLink2URL: http://digikey.com/product-detail/en/ERJ-8ENF1002V/P10.0KFTR-ND/89059; ComponentLink2Description: Digi-Key listing; ComponentLink1URL: http://industrial.panasonic.com/www-cgi/jvcr13pz.cgi?E+PZ+3+AOA0002+ERJ8ENF1002V+7+WW; ComponentLink1Description: Datasheet; Component Kind: Standard; Case Code (Metric): 3216; Case Code (Imperial): 1206; Case/Package: 1206
R5 R27 = ERJ-3EKF1001V: RES SMD 0 OHM JUMPER 1/10W 0603; Manufacturer Part Number 1: ERJ-3GEY0R00V; Manufacturer 1: Panasonic; Voltage Rating (DC): 50V; Voltage Rating: 75V; Tolerance: 0%; Temperature Coefficient: 600ppm/°C; Resistance: 0mR; Power Rating: 100mW; Description 1: Res Thick Film 0603 0 Ohm Jumper Molded SMD Punched Carrier T/R; Database Table Name: Resistor - Chip; Width: 0.031inch; Termination: SMD/SMT; Supplier Part Number 1: P0.0GDKR-ND; Supplier 1: Digi-Key; Stock 1: 17444338; RoHS 1: Yes; REACH SVHC: No SVHC; Radiation Hardening: No; Pricing 1: 1 = 0.10000, 10 = 0.03800, 25 = 0.02760, 50 = 0.02120, 100 = 0.01560, 250 = 0.01188, 500 = 0.00950, 1000 = 0.00700 (USD); Packaging: Tape and Reel; Package Quantity: 1; Number of Terminations: 2; Mount: Surface Mount; Min Operating Temperature: -55°C; Military Standard: Not; Max Power Dissipation: 100mW; Max Operating Temperature: 155°C; Library Name: Celestial Library Database.DbLib; Length: 1.6mm; Lead Free: Lead Free; Height: 0.45mm; Features: Automotive AEC-Q200; Depth: 0.8mm; Contact Plating: Tin; Composition: Thick Film; ComponentLink14URL: https://datasheet.ciiva.com/30199/4aoa0000ce1-30199822.pdf?src-supplier=Onlinecomponents.com; ComponentLink14Description: https://datasheet.ciiva.com/30199/4aoa0000ce1-30199822.pdf?src-supplier=Onlinecomponents.com; ComponentLink13URL: https://datasheet.ciiva.com/17215/aoa0000ce1-17215388.pdf?src-supplier=Avnet+Europe; ComponentLink13Description: https://datasheet.ciiva.com/17215/aoa0000ce1-17215388.pdf?src-supplier=Avnet+Europe; ComponentLink12URL: https://datasheet.ciiva.com/16834/aoa0000ce1-16834828.pdf?src-supplier=Future+Electronics; ComponentLink12Description: https://datasheet.ciiva.com/16834/aoa0000ce1-16834828.pdf?src-supplier=Future+Electronics; ComponentLink11URL: https://datasheet.ciiva.com/16758/70255313-16758527.pdf?src-supplier=Allied+Electronics; ComponentLink11Description: https://datasheet.ciiva.com/16758/70255313-16758527.pdf?src-supplier=Allied+Electronics; ComponentLink10URL: https://datasheet.ciiva.com/9198/aoa0000ce1-9198975.pdf?src-supplier=Avnet; ComponentLink10Description: https://datasheet.ciiva.com/9198/aoa0000ce1-9198975.pdf?src-supplier=Avnet; ComponentLink9URL: https://datasheet.ciiva.com/46377/1678796-46377868.pdf?src-supplier=Element14; ComponentLink9Description: https://datasheet.ciiva.com/46377/1678796-46377868.pdf?src-supplier=Element14; ComponentLink8URL: https://datasheet.ciiva.com/5959/1678796-5959707.pdf?src-supplier=Farnell; ComponentLink8Description: https://datasheet.ciiva.com/5959/1678796-5959707.pdf?src-supplier=Farnell; ComponentLink7URL: https://datasheet.ciiva.com/28695/1678796-28695833.pdf?src-supplier=Element14; ComponentLink7Description: https://datasheet.ciiva.com/28695/1678796-28695833.pdf?src-supplier=Element14; ComponentLink6URL: https://datasheet.ciiva.com/43460/1678796-43460647.pdf?src-supplier=Farnell; ComponentLink6Description: https://datasheet.ciiva.com/43460/1678796-43460647.pdf?src-supplier=Farnell; ComponentLink5URL: https://datasheet.ciiva.com/48532/aoa0000ce1-48532165.pdf?src-supplier=Avnet; ComponentLink5Description: https://datasheet.ciiva.com/48532/aoa0000ce1-48532165.pdf?src-supplier=Avnet; ComponentLink4URL: https://datasheet.ciiva.com/11808/0900766b80f806dd-11808207.pdf?src-supplier=RSComponents; ComponentLink4Description: https://datasheet.ciiva.com/11808/0900766b80f806dd-11808207.pdf?src-supplier=RSComponents; ComponentLink3URL: https://datasheet.ciiva.com/27055/1935748-27055113.pdf?src-supplier=Jameco; ComponentLink3Description: https://datasheet.ciiva.com/27055/1935748-27055113.pdf?src-supplier=Jameco; ComponentLink2URL: http://digikey.com/product-detail/en/ERJ-3EKF1001V/P1.00KHTR-ND/196032; ComponentLink2Description: Digi-Key listing; ComponentLink1URL: http://industrial.panasonic.com/www-cgi/jvcr13pz.cgi?E+PZ+3+AOA0002+ERJ3EKF1001V+7+WW; ComponentLink1Description: Datasheet; Component Kind: Standard; Case Code (Metric): 1608; Case Code (Imperial): 0603; Case/Package: 0603
RE1 = RELAY-SPDT: RELAY GEN PURPOSE SPDT 20A 12V; Manufacturer Part Number 1: T9GV5L14-12; Manufacturer 1: TE Connectivity P&B; Release Time: 22ms; Relay Type: General Purpose; Operate Time: 15ms; Coil Type: Non-Latching; Coil Resistance: 160R; Coil Power: 900mW; Coil Current: 75mA; Throw Configuration: SPDT; Termination: PC Pin; Supplier Part Number 1: PB2355-ND; Supplier 1: Digi-Key; Stock 1: 1403; RoHS 1: No; Pricing 1: 1 = 4.10000, 10 = 3.84800, 25 = 3.42000, 50 = 3.24900, 100 = 3.07800, 250 = 2.73600, 500 = 2.56500, 1000 = 2.39400, 5000 = 2.30850 (USD); Packaging: Bulk; Mount: Through Hole; Min Operating Temperature: -40°C; Max Operating Temperature: 105°C; Library Name: Schlib1.SchLib; Contact Material: Tin,Silver; Contact Current Rating: 20A; ComponentLink1URL: https://www.te.com/commerce/DocumentDelivery/DDEController?Action=srchrtrv&amp;DocNm=T9G_1216&amp;DocType=DS&amp;DocLang=English&src-supplier=Digi-Key; ComponentLink1Description: https://www.te.com/commerce/DocumentDelivery/DDEController?Action=srchrtrv&amp;DocNm=T9G_1216&amp;DocType=DS&amp;DocLang=English&src-supplier=Digi-Key; Component Kind: Standard; Coil Voltage (DC): 12V
TP1-TP4 TP6-TP11 = TP: Test Point; Manufacturer 1: N/A; Library Name: Schlib1.SchLib; Component Kind: Standard
